# Altium schematic sheet Octo.SchDoc(Octo)
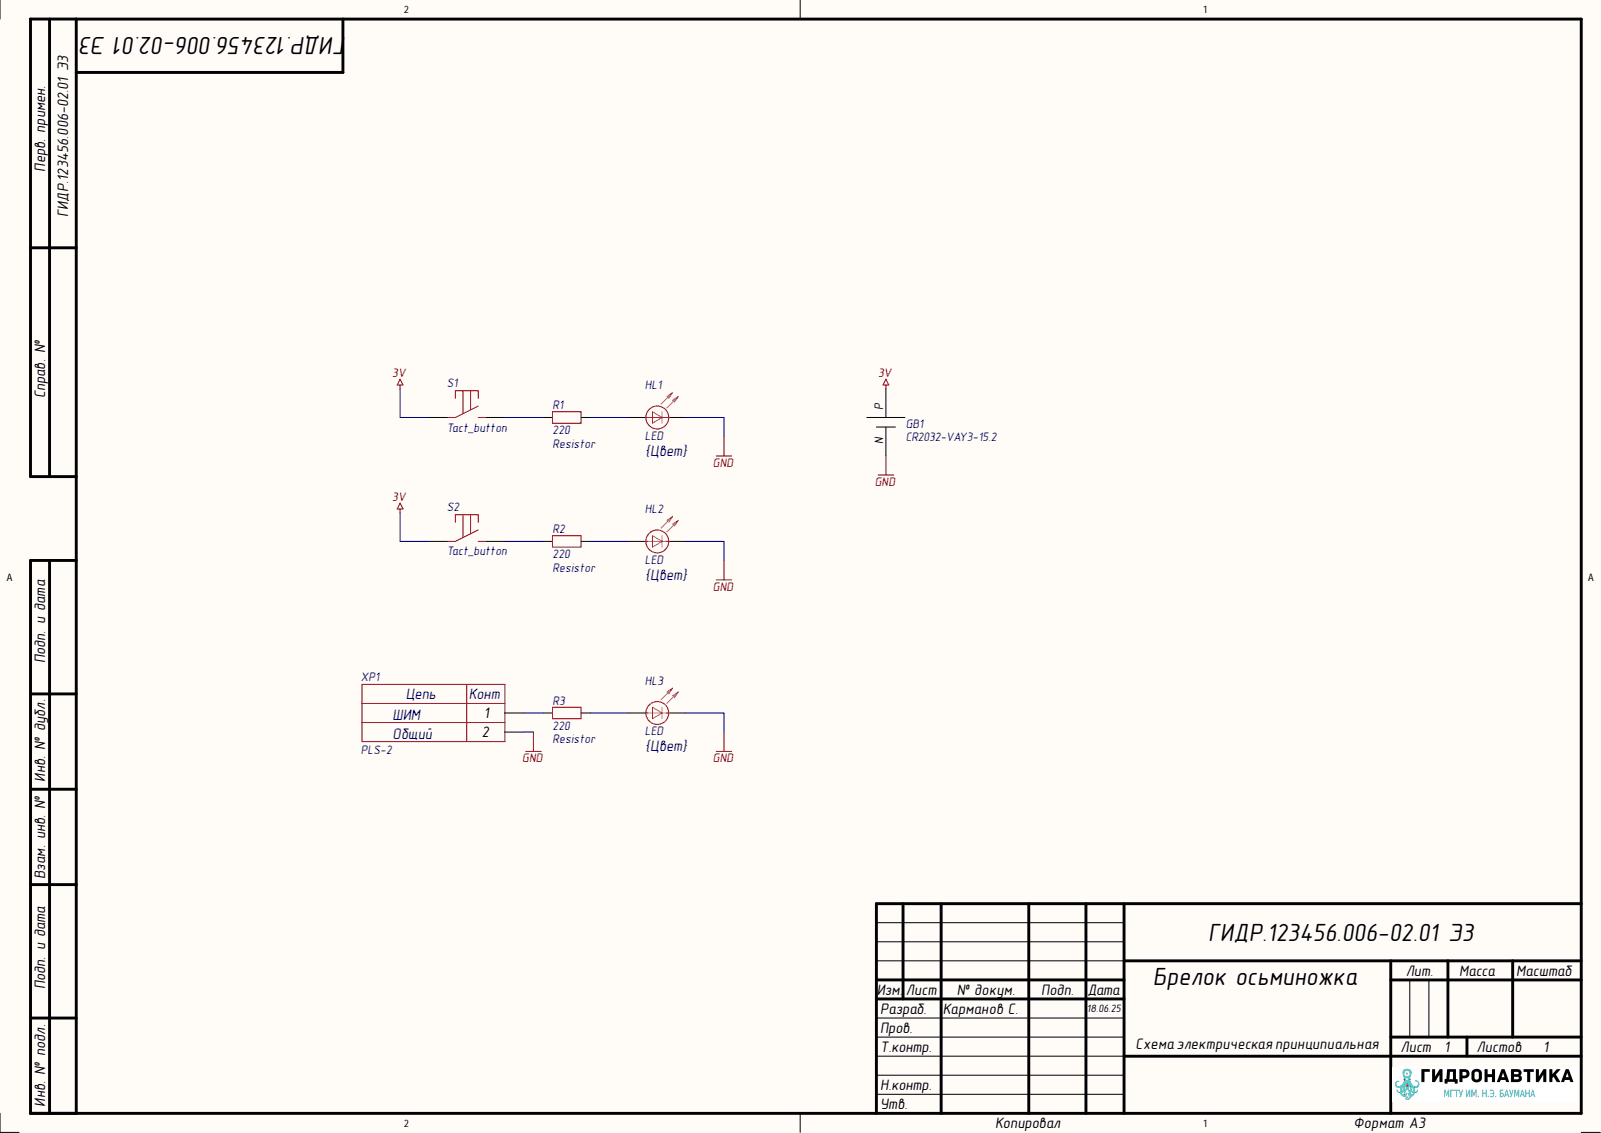
[diagram p0: Octo.SchDoc]

## Parts
GB1  CR2032-VAY3-15.2  [CR2032-VAY3-15.2]
HL1  LED  Color: {Цвет}  [3mm_led_red]
HL2  LED  Color: {Цвет}  [3mm_led_red]
HL3  LED  Color: {Цвет}  [3mm_led_red]
R1  Resistor  value 220  [RES_THT_025W_220]
R2  Resistor  value 220  [RES_THT_025W_220]
R3  Resistor  value 220  [RES_THT_025W_220]
S1  Tact_button  [Button_tht_6X6]
S2  Tact_button  [Button_tht_6X6]
XP1  PLS-2  Value1: ШИМ  Value2: Общий  [PLS2]

## Nets
3V: GB1.P S1.1 S2.1
GND: GB1.N HL1.2 HL2.2 HL3.2 XP1.2
NetHL1_1: HL1.1 R1.2
NetHL2_1: HL2.1 R2.2
NetHL3_1: HL3.1 R3.2
NetR1_1: R1.1 S1.2
NetR2_1: R2.1 S2.2
NetR3_1: R3.1 XP1.1

## Library data of the parts
GB1 = CR2032-VAY3-15.2: Li, MnO2 батарея типоразмера R20, 3В, 0.22Ач, приваренные выводы, -20...60 °C; Database Table Name: Other$; Component Kind: Standard; Library Name: Hydrolib.DbLib; Part Number: CR2032-VAY3-15.2
HL1-HL3 = LED: Светодиод; Database Table Name: Other$; Component Kind: Standard; Library Name: Hydrolib.DbLib; Part Number: LED
R1-R3 = Resistor_220_1_0603: Резистор номиналом 220 Ом и точностью 1%; Database Table Name: Resistors$; Component Kind: Standard; Library Name: Hydrolib.DbLib; Part Number: Resistor_220_1_0603; Tolerance: 1%
S1 S2 = Tact_button: Тактовая кнопка; Database Table Name: Other$; Component Kind: Standard; Library Name: Hydrolib.DbLib; Part Number: Tact_button
XP1 = PLS-2: Гребенка 2 пина; Database Table Name: Connectors$; System: Connector; Component Kind: Standard; Library Name: Hydrolib.DbLib; Part Number: PLS-2
